# Altium schematic sheet main sheet.SchDoc
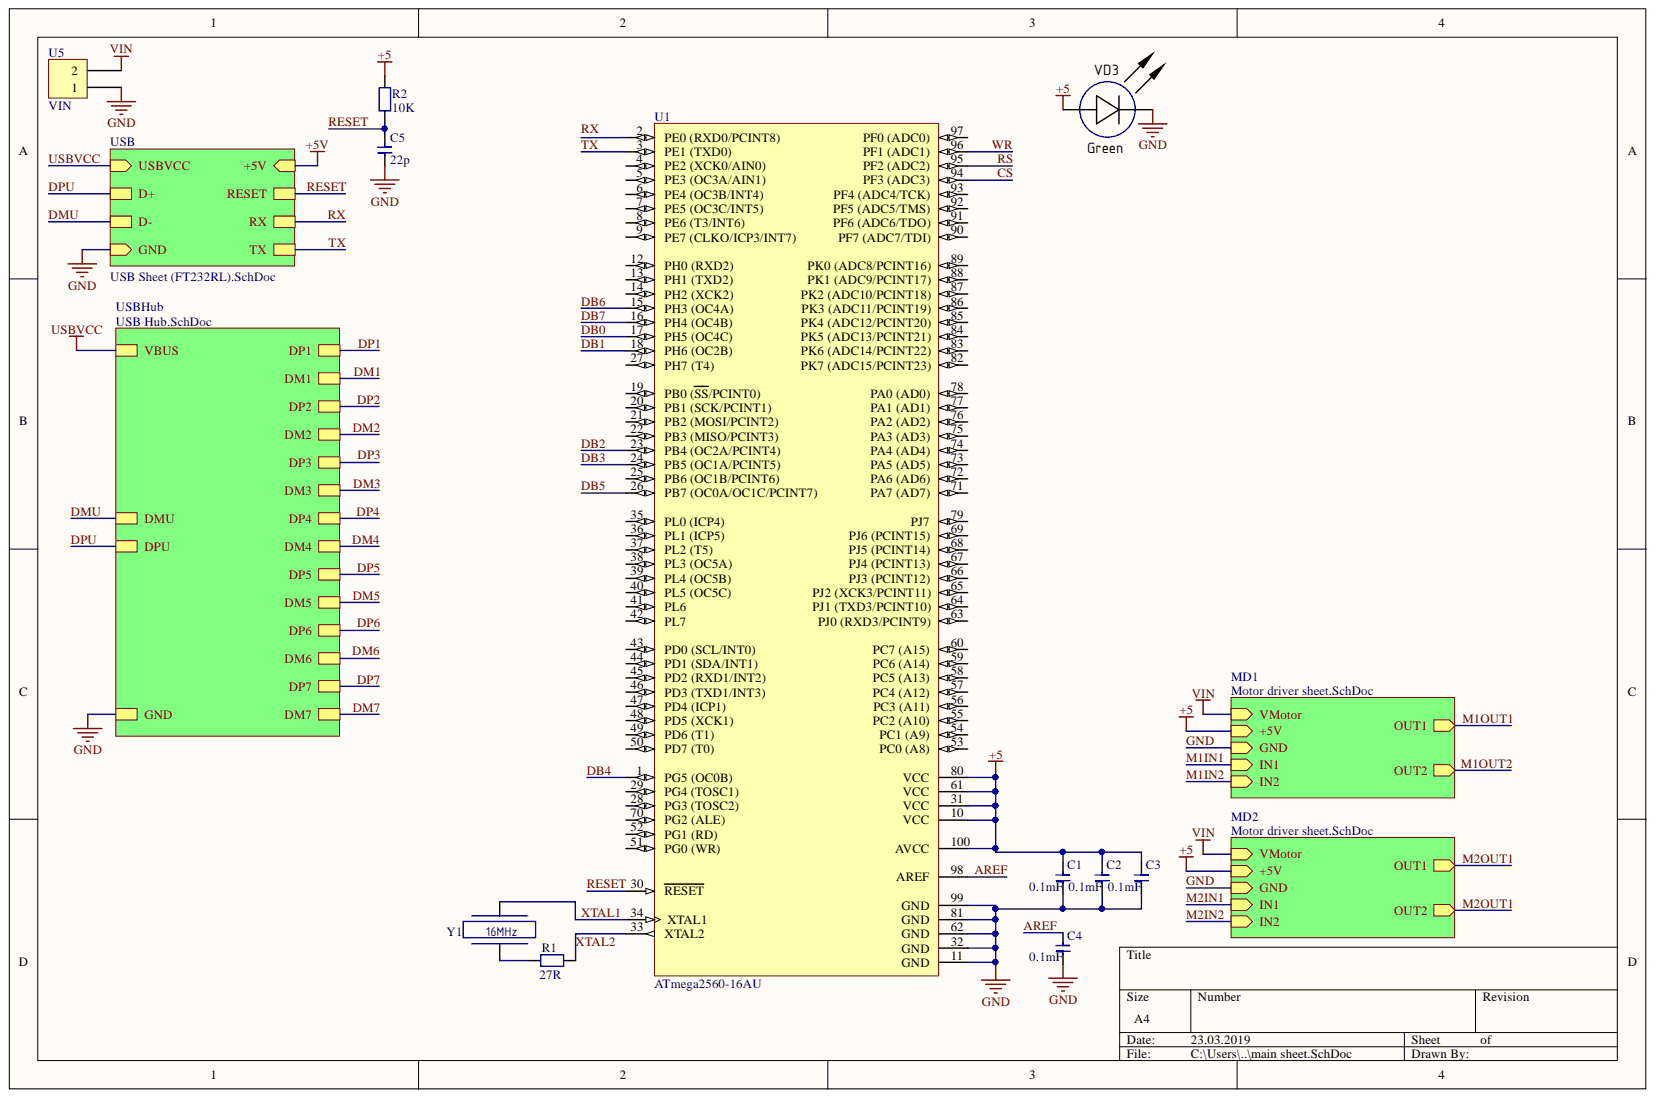
[diagram p0: main sheet.SchDoc]

## Parts
C1  0.1mF  [cap0402]
C2  0.1mF  [cap0402]
C3  0.1mF  [cap0402]
C4  0.1mF  [cap0402]
C5  22p  [cap0603]
R1  27R  [res0603]
R2  10K  [res0603]
U1  ATmega2560-16AU  [100A_N]
U5  VIN  [Power connectors]
VD3  Green  [CH0603LB]
Y1  16MHz  [QSMD5.0x3.2x1.0]

## Nets
+5: C1.2 C2.2 C3.2 R2.2 U1.10(VCC) U1.31(VCC) U1.61(VCC) U1.80(VCC) U1.100(AVCC) VD3.1
VIN: U5.2
AREF: C4.2 U1.98(AREF)
CS: U1.94(PF3_(ADC3))
DB0: U1.17(PH5_(OC4C))
DB1: U1.18(PH6_(OC2B))
DB2: U1.23(PB4_(OC2A/PCINT4))
DB3: U1.24(PB5_(OC1A/PCINT5))
DB4: U1.1(PG5_(OC0B))
DB5: U1.26(PB7_(OC0A/OC1C/PCINT7))
DB6: U1.15(PH3_(OC4A))
DB7: U1.16(PH4_(OC4B))
GND: C1.1 C2.1 C3.1 C4.1 C5.1 U1.11(GND) U1.32(GND) U1.62(GND) U1.81(GND) U1.99(GND) U5.1 VD3.2
RESET: C5.2 R2.1 U1.30(RESET)
RS: U1.95(PF2_(ADC2))
TX: U1.3(PE1_(TXD0))
WR: U1.96(PF1_(ADC1))
XTAL1: U1.34(XTAL1) Y1.2
XTAL2: R1.2 U1.33(XTAL2)
NetR1_1: R1.1 Y1.1
NetU1_2: U1.2(PE0_(RXD0/PCINT8))
NetU1_4: U1.4(PE2_(XCK0/AIN0))
NetU1_5: U1.5(PE3_(OC3A/AIN1))
NetU1_6: U1.6(PE4_(OC3B/INT4))
NetU1_7: U1.7(PE5_(OC3C/INT5))
NetU1_8: U1.8(PE6_(T3/INT6))
NetU1_9: U1.9(PE7_(CLKO/ICP3/INT7))
NetU1_12: U1.12(PH0_(RXD2))
NetU1_13: U1.13(PH1_(TXD2))
NetU1_14: U1.14(PH2_(XCK2))
NetU1_19: U1.19(PB0_(SS/PCINT0))
NetU1_20: U1.20(PB1_(SCK/PCINT1))
NetU1_21: U1.21(PB2_(MOSI/PCINT2))
NetU1_22: U1.22(PB3_(MISO/PCINT3))
NetU1_25: U1.25(PB6_(OC1B/PCINT6))
NetU1_27: U1.27(PH7_(T4))
NetU1_28: U1.28(PG3_(TOSC2))
NetU1_29: U1.29(PG4_(TOSC1))
NetU1_35: U1.35(PL0_(ICP4))
NetU1_36: U1.36(PL1_(ICP5))
NetU1_37: U1.37(PL2_(T5))
NetU1_38: U1.38(PL3_(OC5A))
NetU1_39: U1.39(PL4_(OC5B))
NetU1_40: U1.40(PL5_(OC5C))
NetU1_41: U1.41(PL6)
NetU1_42: U1.42(PL7)
NetU1_43: U1.43(PD0_(SCL/INT0))
NetU1_44: U1.44(PD1_(SDA/INT1))
NetU1_45: U1.45(PD2_(RXD1/INT2))
NetU1_46: U1.46(PD3_(TXD1/INT3))
NetU1_47: U1.47(PD4_(ICP1))
NetU1_48: U1.48(PD5_(XCK1))
NetU1_49: U1.49(PD6_(T1))
NetU1_50: U1.50(PD7_(T0))
NetU1_51: U1.51(PG0_(WR))
NetU1_52: U1.52(PG1_(RD))
NetU1_53: U1.53(PC0_(A8))
NetU1_54: U1.54(PC1_(A9))
NetU1_55: U1.55(PC2_(A10))
NetU1_56: U1.56(PC3_(A11))
NetU1_57: U1.57(PC4_(A12))
NetU1_58: U1.58(PC5_(A13))
NetU1_59: U1.59(PC6_(A14))
NetU1_60: U1.60(PC7_(A15))
NetU1_63: U1.63(PJ0_(RXD3/PCINT9))
NetU1_64: U1.64(PJ1_(TXD3/PCINT10))
NetU1_65: U1.65(PJ2_(XCK3/PCINT11))
NetU1_66: U1.66(PJ3_(PCINT12))
NetU1_67: U1.67(PJ4_(PCINT13))
NetU1_68: U1.68(PJ5_(PCINT14))
NetU1_69: U1.69(PJ6_(PCINT15))
NetU1_70: U1.70(PG2_(ALE))
NetU1_71: U1.71(PA7_(AD7))
NetU1_72: U1.72(PA6_(AD6))
NetU1_73: U1.73(PA5_(AD5))
NetU1_74: U1.74(PA4_(AD4))
NetU1_75: U1.75(PA3_(AD3))
NetU1_76: U1.76(PA2_(AD2))
NetU1_77: U1.77(PA1_(AD1))
NetU1_78: U1.78(PA0_(AD0))
NetU1_79: U1.79(PJ7)
NetU1_82: U1.82(PK7_(ADC15/PCINT23))
NetU1_83: U1.83(PK6_(ADC14/PCINT22))
NetU1_84: U1.84(PK5_(ADC13/PCINT21))
NetU1_85: U1.85(PK4_(ADC12/PCINT20))
NetU1_86: U1.86(PK3_(ADC11/PCINT19))
NetU1_87: U1.87(PK2_(ADC10/PCINT18))
NetU1_88: U1.88(PK1_(ADC9/PCINT17))
NetU1_89: U1.89(PK0_(ADC8/PCINT16))
NetU1_90: U1.90(PF7_(ADC7/TDI))
NetU1_91: U1.91(PF6_(ADC6/TDO))
NetU1_92: U1.92(PF5_(ADC5/TMS))
NetU1_93: U1.93(PF4_(ADC4/TCK))
NetU1_97: U1.97(PF0_(ADC0))
+5V:
DM1:
DM2:
DM3:
DM4:
DM5:
DM6:
DM7:
DMU:
DP1:
DP2:
DP3:
DP4:
DP5:
DP6:
DP7:
DPU:
M1IN1:
M1IN2:
M1OUT1:
M1OUT2:
M2IN1:
M2IN2:
M2OUT1:
RX:
USBVCC:

## Library data of the parts
C1-C5 = Cap SMD: Component Kind: Standard; Library Name: AlexChistiakov.SchLib
R1 R2 = Resistor SMD: Component Kind: Standard; Library Name: AlexChistiakov.SchLib
U1 = ATmega2560-16AU: 8-bit AVR Microcontroller, 4.5-5.5V, 256KB Flash, 4KB EEPROM, 8KB SRAM, 86 GPIO pins, 100-pin TQFP, Industrial Grade (-40°C to 85°C), Pb-Free; Code_JEDEC: MS-026-AED; Component Kind: Standard; ComponentLink1Description: Manufacturer Link; ComponentLink1URL: http://www.atmel.com/products/avr/default.asp?; ComponentLink2Description: ATmega640/1280/1281/2560/2561 Datasheet; ComponentLink2URL: http://www.atmel.com/dyn/resources/prod_documents/doc2549.pdf; ComponentLink3Description: ATmega640/1280/1281/2560/2561 Summary; ComponentLink3URL: http://www.atmel.com/dyn/resources/prod_documents/2549S.pdf; ComponentLink4Description: Atmel.com Home; ComponentLink4URL: http://www.atmel.com; DatasheetVersion: revL, Aug-2007; Library Name: Atmel Microcontroller 8-Bit megaAVR.IntLib; PackageDescription: 100-Pin Thin Profile Plastic Quad Flat Pack (TQFP), 14 x 14 x 1.0 mm Body, 0.5 mm Pitch; PackageReference: 100A; PackageVersion: revC, Oct-2001; Published: 1-Apr-2010; Supplier 1: Digi-Key; Supplier 2: Farnell; Supplier 3: Farnell; Supplier 4: Mouser; Supplier Part Number 1: ATMEGA2560-16AU-ND; Supplier Part Number 2: 1288330; Supplier Part Number 3: 1661740; Supplier Part Number 4: 556-ATMEGA2560-16AU; Publisher: Altium Limited
U5 = Power connectors: Component Kind: Standard; Library Name: AlexChistiakov.SchLib
VD3 = LED: Component Kind: Standard; Library Name: Discrete.SchLib
Y1 = Crystal: Кварцевый резонатор; Component Kind: Standard; Value: 16MHz; Library Name: TarabusLib_KOR.SchLib
